# Altium schematic sheet LPF.SchDoc(LPF)
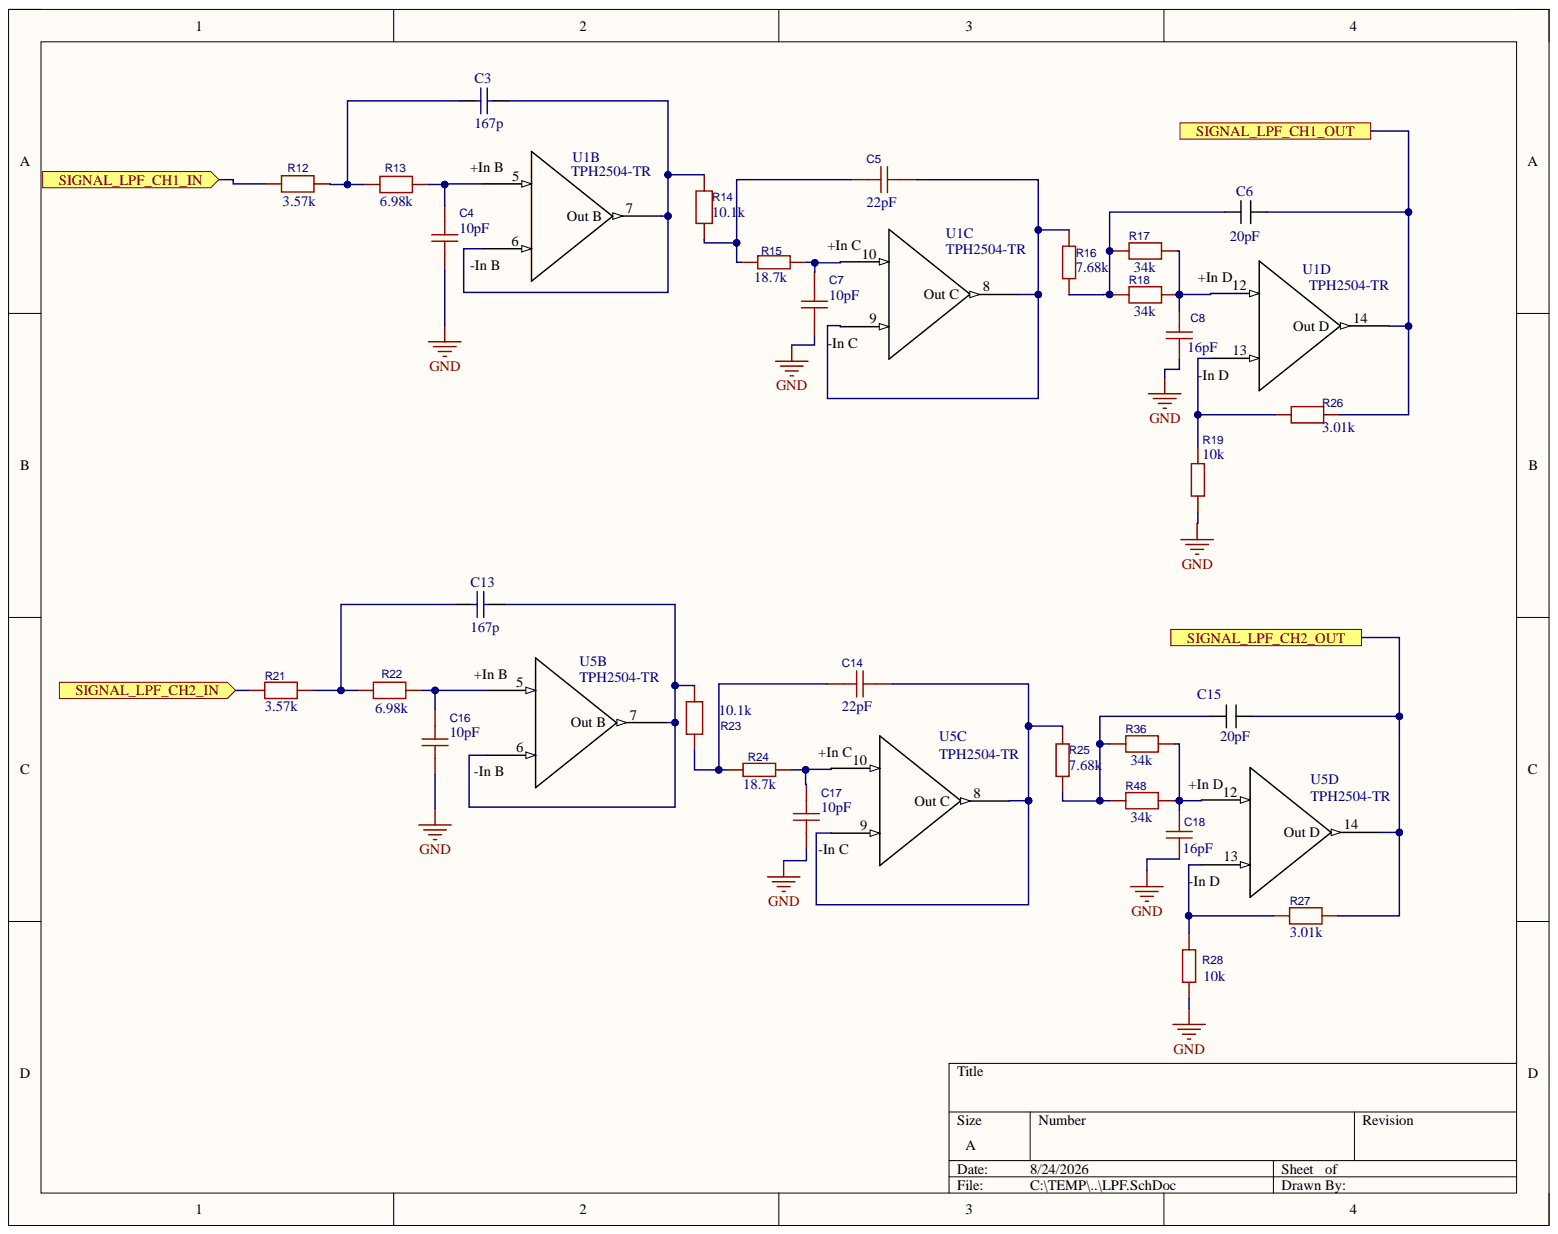
[diagram p0: LPF.SchDoc]

## Parts
C3  value 167p  (hidden comment: Capacitor)
C4  value 10pF  [C0805]  (hidden comment: VJ0805A100FXACW1BC)
C5  value 22pF  [C0805]  (hidden comment: TCC0805X5R226K100FT)
C6  value 20pF  [CAPCP2012X150N]  (hidden comment: Capacitor)
C7  value 10pF  [C0805]  (hidden comment: VJ0805A100FXACW1BC)
C8  value 16pF  [C0805]  (hidden comment: 0805CG6R0C500NT_C67560)
C13  value 167p  (hidden comment: Capacitor)
C14  value 22pF  [C0805]  (hidden comment: TCC0805X5R226K100FT)
C15  value 20pF  [CAPCP2012X150N]  (hidden comment: Capacitor)
C16  value 10pF  [C0805]  (hidden comment: VJ0805A100FXACW1BC)
C17  value 10pF  [C0805]  (hidden comment: VJ0805A100FXACW1BC)
C18  value 16pF  [C0805]  (hidden comment: 0805CG6R0C500NT_C67560)
R12  value 3.57k  [R0805]  (hidden comment: RT0805BRD0710K1L)
R13  value 6.98k  [R0805]  (hidden comment: RT0805BRD0710K1L)
R14  value 10.1k  [R0805]  (hidden comment: RT0805BRD0710K1L)
R15  value 18.7k  [R0805]  (hidden comment: 0805W8F0000T5E_C17477)
R16  value 7.68k  [R0805]  (hidden comment: 0805W8F0000T5E_C17477)
R17  value 34k  [R0805]  (hidden comment: FRC0805F3402TS)
R18  value 34k  [R0805]  (hidden comment: FRC0805F3402TS)
R19  value 10k  [R0805]  (hidden comment: 0805W8F0000T5E_C17477)
R21  value 3.57k  [R0805]  (hidden comment: RT0805BRD0710K1L)
R22  value 6.98k  [R0805]  (hidden comment: RT0805BRD0710K1L)
R23  value 10.1k  [R0805]  (hidden comment: RT0805BRD0710K1L)
R24  value 18.7k  [R0805]  (hidden comment: 0805W8F0000T5E_C17477)
R25  value 7.68k  [R0805]  (hidden comment: 0805W8F0000T5E_C17477)
R26  value 3.01k  [R0805]  (hidden comment: RT0805BRD0710K1L)
R27  value 3.01k  [R0805]  (hidden comment: RT0805BRD0710K1L)
R28  value 10k  [R0805]  (hidden comment: 0805W8F0000T5E_C17477)
R36  value 34k  [R0805]  (hidden comment: FRC0805F3402TS)
R48  value 34k  [R0805]  (hidden comment: FRC0805F3402TS)
U1  TPH2504-TR  [TSOP65P640X120-14N]  (parts B,C,D)
U5  TPH2504-TR  [TSOP65P640X120-14N]  (parts B,C,D)

## Ports
SIGNAL_LPF_CH1_IN, SIGNAL_LPF_CH1_OUT, SIGNAL_LPF_CH2_IN, SIGNAL_LPF_CH2_OUT

## Nets
GND: C4.1 C7.1 C8.2 C16.1 C17.1 C18.2 R19.1 R28.1
SIGNAL_LPF_CH1_IN: R12.1 ; port SIGNAL_LPF_CH1_IN
SIGNAL_LPF_CH1_OUT: C6.1 R26.2 ; port SIGNAL_LPF_CH1_OUT
SIGNAL_LPF_CH2_IN: R21.2 ; port SIGNAL_LPF_CH2_IN
SIGNAL_LPF_CH2_OUT: C15.1 R27.1 U5.14(Out_D) ; port SIGNAL_LPF_CH2_OUT
NetC3_1: C3.1 R14.2 U1.6 U1.7(Out_B)
NetC3_2: C3.2 R12.2 R13.1
NetC4_2: C4.2 R13.2 U1.5
NetC5_1: C5.1 R14.1 R15.1
NetC5_2: C5.2 R16.2 U1.8(Out_C) U1.9
NetC6_2: C6.2 R16.1 R17.1 R18.1
NetC7_2: C7.2 R15.2 U1.10
NetC8_1: C8.1 R17.2 R18.2 U1.12
NetC13_1: C13.1 R23.1 U5.6 U5.7(Out_B)
NetC13_2: C13.2 R21.1 R22.1
NetC14_1: C14.1 R23.2 R24.1
NetC14_2: C14.2 R25.2 U5.8(Out_C) U5.9
NetC15_2: C15.2 R25.1 R36.1 R48.1
NetC16_2: C16.2 R22.2 U5.5
NetC17_2: C17.2 R24.2 U5.10
NetC18_1: C18.1 R36.2 R48.2 U5.12
NetR19_2: R19.2 R26.1 U1.13
NetR27_2: R27.2 R28.2 U5.13

Not connected: U1 14(Out_D)

## Library data of the parts
C3 C13 = Capacitor: SimulationGenericComponent: True; Component Kind: Standard; Description: Capacitor; Library Name: Simulation Generic Components.IntLib; Simulation: C_Capacitor_Default
C4 C7 C16 C17 = CMP-015-000004-1: Capacitance:10pF Tolerance:±1% Tolerance:±1% Voltage Rating:50V Temperature Coefficient:C0G; Manufacturer: VISHAY(威世); AddInBom: yes; ModelId: 8c88e81f49c6409b980720ec8953afb6?0819f05c4eef4c71ace90d822a990e87; ModelName: C0805_L2.0-W1.3-H1.3; ModelTransform: 78.74,51.181,0,0,0,0,0,0.0005,0; Temperature Coefficient: C0G; Tolerance: 1%; Voltage Rating: 50V; Component Kind: Standard; ConvertToPcb: yes; Datasheet: https://item.szlcsc.com/datasheet/VJ0805A100FXACW1BC/2269676.html; Design Item ID: VJ0805A100FXACW1BC; Device: VJ0805A100FXACW1BC; FootprintName: C0805; JLCPCB Part Class: Extended Part; LCSC Part Name: 10pF ±1% 50V; Manufacturer Part Number: VJ0805A100FXACW1BC; Source: P1.SCHLIB; Supplier Footprint: 0805; Supplier Part Number: C2177610; Symbol: VJ0805A100FXACW1BC; Library Name: zhiming xue
C5 C14 = CMP-015-000002-1: Capacitance:22pF Tolerance:±1% Tolerance:±1% Voltage Rating:50V Temperature Coefficient:C0G; Manufacturer: FH(?华); AddInBom: yes; Device: 0805CG220F500NT; ModelId: 8c88e81f49c6409b980720ec8953afb6?0819f05c4eef4c71ace90d822a990e87; ModelName: C0805_L2.0-W1.3-H1.3; ModelTransform: 78.74,51.181,0,0,0,0,0,0.0005,0; Temperature Coefficient: C0G; Tolerance: 1%; Voltage Rating: 50V; Component Kind: Standard; ConvertToPcb: yes; Datasheet: https://item.szlcsc.com/datasheet/0805CG220F500NT/208470.html; Design Item ID: 0805CG220F500NT; FootprintName: C0805; JLCPCB Part Class: Extended Part; LCSC Part Name: 22pF ±1% 50V; Manufacturer Part Number: 0805CG220F500NT; Source: P1.SCHLIB; Supplier Footprint: 0805; Supplier Part Number: C190088; Symbol: 0805CG220F500NT; Library Name: zhiming xue
C6 C15 = CMP-00003-4: Mlcc 20PF ±5% C0G(NP0) 50V 0805; Manufacturer: CCTC; Manufacturer Part Number: TCC0805COG200J500BT; Component Kind: Standard; Supplier: JLCPCB; Supplier Part Number: C5375851; Library Name: zhiming xue
C8 C18 = CMP-015-000001-1: Capacitance:16pF Tolerance:±5% Tolerance:±5% Voltage Rating:50V Temperature Coefficient:C0G; Manufacturer: FH(?华); AddInBom: yes; Device: 0805CG160J500NT; ModelId: 8c88e81f49c6409b980720ec8953afb6?0819f05c4eef4c71ace90d822a990e87; ModelName: C0805_L2.0-W1.3-H1.3; ModelTransform: 78.74,51.181,0,0,0,0,0,0.0005,0; Temperature Coefficient: C0G; Tolerance: 5%; Voltage Rating: 50V; Component Kind: Standard; ConvertToPcb: yes; Datasheet: https://item.szlcsc.com/datasheet/0805CG160J500NT/2148.html; Design Item ID: 0805CG160J500NT; FootprintName: C0805; JLCPCB Part Class: Extended Part; LCSC Part Name: 16pF ±5% 50V; Manufacturer Part Number: 0805CG160J500NT; Source: P1.SCHLIB; Supplier Footprint: 0805; Supplier Part Number: C1796; Symbol: 0805CG160J500NT; Library Name: zhiming xue
R12 R21 = CMP-016-000012-1: Type:Thick Film Resistor Resistance:3.57kΩ Tolerance:±1% Tolerance:±1% Power(Watts):125mW Voltage-Supply(Max):150V Temperature Coefficient:±100ppm/℃ Temperature Coefficient:±100ppm/℃ Operating Temperature:-55℃~+155℃ Operating Temperature:-55℃~+155℃; Manufacturer: FOJAN(富捷); AddInBom: yes; Device: FRC0805F3571TS; ModelId: b83c7c5430874b8eb2319a65d9433429?0819f05c4eef4c71ace90d822a990e87; ModelName: R0805_L2.0-W1.3-H0.6; ModelTransform: 78.74,51.181,0,0,0,0,0,-0.0005,0; Power(Watts): 125mW; Tolerance: 1%; Voltage-Supply(Max): 150V; Component Kind: Standard; ConvertToPcb: yes; Datasheet: https://item.szlcsc.com/datasheet/FRC0805F3571TS/3279527.html; Design Item ID: FRC0805F3571TS; FootprintName: R0805; JLCPCB Part Class: Extended Part; LCSC Part Name: 3.57kΩ ±1% 125mW 厚?电?; Manufacturer Part Number: FRC0805F3571TS; Operating Temperature: -55℃~+155℃; Source: P1.SCHLIB; Supplier Footprint: 0805; Supplier Part Number: C2933425; Symbol: FRC0805F3571TS; Temperature Coefficient: ±100ppm/℃; Type: Thick Film Resistor; Library Name: zhiming xue
R13 R22 = CMP-016-000014-1: Type:Thick Film Resistor Resistance:6.98kΩ Tolerance:±1% Tolerance:±1% Power(Watts):125mW Voltage-Supply(Max):150V Temperature Coefficient:±100ppm/℃ Temperature Coefficient:±100ppm/℃ Operating Temperature:-55℃~+155℃ Operating Temperature:-55℃~+155℃; Manufacturer: FOJAN(富捷); AddInBom: yes; Device: FRC0805F6981TS; ModelId: b83c7c5430874b8eb2319a65d9433429?0819f05c4eef4c71ace90d822a990e87; ModelName: R0805_L2.0-W1.3-H0.6; ModelTransform: 78.74,51.181,0,0,0,0,0,-0.0005,0; Power(Watts): 125mW; Tolerance: 1%; Voltage-Supply(Max): 150V; Component Kind: Standard; ConvertToPcb: yes; Datasheet: https://item.szlcsc.com/datasheet/FRC0805F6981TS/3279591.html; Design Item ID: FRC0805F6981TS; FootprintName: R0805; JLCPCB Part Class: Extended Part; LCSC Part Name: 6.98kΩ ±1% 125mW 厚?电?; Manufacturer Part Number: FRC0805F6981TS; Operating Temperature: -55℃~+155℃; Source: P1.SCHLIB; Supplier Footprint: 0805; Supplier Part Number: C2933489; Symbol: FRC0805F6981TS; Temperature Coefficient: ±100ppm/℃; Type: Thick Film Resistor; Library Name: zhiming xue
R14 R23 = CMP-016-000017-1: Type:Thin Film Resistor Resistance:10.1kΩ Tolerance:±0.1% Tolerance:±0.1% Power(Watts):125mW Temperature Coefficient:±25ppm/℃ Temperature Coefficient:±25ppm/℃ Operating Temperature:-55℃~+155℃ Operating Temperature:-55℃~+155℃; Manufacturer: YAGEO(国巨); AddInBom: yes; ModelId: b83c7c5430874b8eb2319a65d9433429?0819f05c4eef4c71ace90d822a990e87; ModelName: R0805_L2.0-W1.3-H0.6; ModelTransform: 78.74,51.181,0,0,0,0,0,-0.0005,0; Power(Watts): 125mW; Tolerance: 0.1%; Component Kind: Standard; ConvertToPcb: yes; Datasheet: https://item.szlcsc.com/datasheet/RT0805BRD0710K1L/925214.html; Design Item ID: RT0805BRD0710K1L; Device: RT0805BRD0710K1L; FootprintName: R0805; JLCPCB Part Class: Extended Part; LCSC Part Name: ??电? 10.1kΩ ±0.1% 125mW; Manufacturer Part Number: RT0805BRD0710K1L; Operating Temperature: -55℃~+155℃; Source: P1.SCHLIB; Supplier Footprint: 0805; Supplier Part Number: C865133; Symbol: RT0805BRD0710K1L; Temperature Coefficient: ±25ppm/℃; Type: Thin Film Resistor; Library Name: zhiming xue
R15 R24 = CMP-016-000004-1: Type:Thick Film Resistor Resistance:18.7kΩ Tolerance:±1% Tolerance:±1% Power(Watts):125mW Voltage-Supply(Max):150V Temperature Coefficient:±100ppm/℃ Temperature Coefficient:±100ppm/℃ Operating Temperature:-55℃~+155℃ Operating Temperature:-55℃~+155℃; Manufacturer: UNI-ROYAL(厚声); AddInBom: yes; Device: 0805W8F1872T5E; ModelId: b83c7c5430874b8eb2319a65d9433429?0819f05c4eef4c71ace90d822a990e87; ModelName: R0805_L2.0-W1.3-H0.6; ModelTransform: 78.74,51.181,0,0,0,0,0,-0.0005,0; Power(Watts): 125mW; Tolerance: 1%; Voltage-Supply(Max): 150V; Component Kind: Standard; ConvertToPcb: yes; Datasheet: https://item.szlcsc.com/datasheet/0805W8F1872T5E/18187.html; Design Item ID: 0805W8F1872T5E; FootprintName: R0805; JLCPCB Part Class: Extended Part; LCSC Part Name: 18.7kΩ ±1% 125mW 厚?电?; Manufacturer Part Number: 0805W8F1872T5E; Operating Temperature: -55℃~+155℃; Source: P1.SCHLIB; Supplier Footprint: 0805; Supplier Part Number: C17499; Symbol: 0805W8F1872T5E; Temperature Coefficient: ±100ppm/℃; Type: Thick Film Resistor; Library Name: zhiming xue
R16 R25 = CMP-016-000016-1: Type:Thick Film Resistor Resistance:7.68kΩ Tolerance:±1% Tolerance:±1% Power(Watts):125mW Voltage-Supply(Max):150V Temperature Coefficient:±100ppm/℃ Temperature Coefficient:±100ppm/℃ Operating Temperature:-55℃~+155℃ Operating Temperature:-55℃~+155℃; Manufacturer: YAGEO(国巨); AddInBom: yes; Device: RC0805FR-077K68L; ModelId: b83c7c5430874b8eb2319a65d9433429?0819f05c4eef4c71ace90d822a990e87; ModelName: R0805_L2.0-W1.3-H0.6; ModelTransform: 78.74,51.181,0,0,0,0,0,-0.0005,0; Power(Watts): 125mW; Tolerance: 1%; Voltage-Supply(Max): 150V; Component Kind: Standard; ConvertToPcb: yes; Datasheet: https://item.szlcsc.com/datasheet/RC0805FR-077K68L/262366.html; Design Item ID: RC0805FR-077K68L; FootprintName: R0805; JLCPCB Part Class: Extended Part; LCSC Part Name: 厚?电? 7.68kΩ ±1% 125mW; Manufacturer Part Number: RC0805FR-077K68L; Operating Temperature: -55℃~+155℃; Source: P1.SCHLIB; Supplier Footprint: 0805; Supplier Part Number: C273951; Symbol: RC0805FR-077K68L; Temperature Coefficient: ±100ppm/℃; Type: Thick Film Resistor; Library Name: zhiming xue
R17 R18 R36 R48 = CMP-016-000011-1: Type:Thick Film Resistor Resistance:34kΩ Tolerance:±1% Tolerance:±1% Power(Watts):125mW Voltage-Supply(Max):150V Temperature Coefficient:±100ppm/℃ Temperature Coefficient:±100ppm/℃ Operating Temperature:-55℃~+155℃ Operating Temperature:-55℃~+155℃; Manufacturer: FOJAN(富捷); AddInBom: yes; ModelId: b83c7c5430874b8eb2319a65d9433429?0819f05c4eef4c71ace90d822a990e87; ModelName: R0805_L2.0-W1.3-H0.6; ModelTransform: 78.74,51.181,0,0,0,0,0,-0.0005,0; Power(Watts): 125mW; Tolerance: 1%; Voltage-Supply(Max): 150V; Component Kind: Standard; ConvertToPcb: yes; Datasheet: https://item.szlcsc.com/datasheet/FRC0805F3402TS/3279522.html; Design Item ID: FRC0805F3402TS; Device: FRC0805F3402TS; FootprintName: R0805; JLCPCB Part Class: Extended Part; LCSC Part Name: 34kΩ ±1% 125mW 厚?电?; Manufacturer Part Number: FRC0805F3402TS; Operating Temperature: -55℃~+155℃; Source: P1.SCHLIB; Supplier Footprint: 0805; Supplier Part Number: C2933420; Symbol: FRC0805F3402TS; Temperature Coefficient: ±100ppm/℃; Type: Thick Film Resistor; Library Name: zhiming xue
R19 R28 = CMP-016-000002-1: Type:Thick Film Resistor Resistance:10kΩ Tolerance:±1% Tolerance:±1% Power(Watts):125mW Voltage-Supply(Max):150V Temperature Coefficient:±100ppm/℃ Temperature Coefficient:±100ppm/℃ Operating Temperature:-55℃~+155℃ Operating Temperature:-55℃~+155℃; Manufacturer: UNI-ROYAL(厚声); AddInBom: yes; Device: 0805W8F1002T5E; ModelId: b83c7c5430874b8eb2319a65d9433429?0819f05c4eef4c71ace90d822a990e87; ModelName: R0805_L2.0-W1.3-H0.6; ModelTransform: 78.74,51.181,0,0,0,0,0,-0.0005,0; Power(Watts): 125mW; Tolerance: 1%; Voltage-Supply(Max): 150V; Component Kind: Standard; ConvertToPcb: yes; Datasheet: https://item.szlcsc.com/datasheet/0805W8F1002T5E/18102.html; Design Item ID: 0805W8F1002T5E; FootprintName: R0805; JLCPCB Part Class: Basic Part; LCSC Part Name: 10kΩ ±1% 125mW 厚?电?; Manufacturer Part Number: 0805W8F1002T5E; Operating Temperature: -55℃~+155℃; Source: P1.SCHLIB; Supplier Footprint: 0805; Supplier Part Number: C17414; Symbol: 0805W8F1002T5E; Temperature Coefficient: ±100ppm/℃; Type: Thick Film Resistor; Library Name: zhiming xue
R26 R27 = CMP-016-000010-1: Type:Thick Film Resistor Resistance:3.01kΩ Tolerance:±1% Tolerance:±1% Power(Watts):125mW Voltage-Supply(Max):150V Temperature Coefficient:±100ppm/℃ Temperature Coefficient:±100ppm/℃ Operating Temperature:-55℃~+155℃ Operating Temperature:-55℃~+155℃; Manufacturer: FOJAN(富捷); AddInBom: yes; Device: FRC0805F3011TS; ModelId: b83c7c5430874b8eb2319a65d9433429?0819f05c4eef4c71ace90d822a990e87; ModelName: R0805_L2.0-W1.3-H0.6; ModelTransform: 78.74,51.181,0,0,0,0,0,-0.0005,0; Power(Watts): 125mW; Tolerance: 1%; Voltage-Supply(Max): 150V; Component Kind: Standard; ConvertToPcb: yes; Datasheet: https://item.szlcsc.com/datasheet/FRC0805F3011TS/3476925.html; Design Item ID: FRC0805F3011TS; FootprintName: R0805; JLCPCB Part Class: Extended Part; LCSC Part Name: 3.01kΩ ±1% 125mW 厚?电?; Manufacturer Part Number: FRC0805F3011TS; Operating Temperature: -55℃~+155℃; Source: P1.SCHLIB; Supplier Footprint: 0805; Supplier Part Number: C2999485; Symbol: FRC0805F3011TS; Temperature Coefficient: ±100ppm/℃; Type: Thick Film Resistor; Library Name: zhiming xue
U1 U5 = CMP-00002-9: Operational Amplifier, 4 Func, 5000uV Offset-Max, CMOS, PDSO14; Common Mode Rejection Ratio: 45dB; GAIN: 120Meg; Input Offset Voltage (Vos): 5mV; Max Supply Current: 48mA; Max Supply Voltage: 7V; Nominal Supply Voltage (DC): 3V; Number of Circuits: 4; Slew Rate: 160V/µs; Unity Gain Bandwidth Product: 120MHz; Case/Package: TSSOP; Component Kind: Standard; Height - Seated (Max): 1.2mm; Length: 4.96mm; Max Operating Temperature: 125°C; Min Operating Temperature: -40°C; Number of Terminals: 14; RoHS: Compliant; Temperature Grade: Automotive; Terminal Pitch: 650µm; Width: 4.4mm; Library Name: zhiming xue
